# Altium schematic sheet True Variant.SchDoc("True Variant")
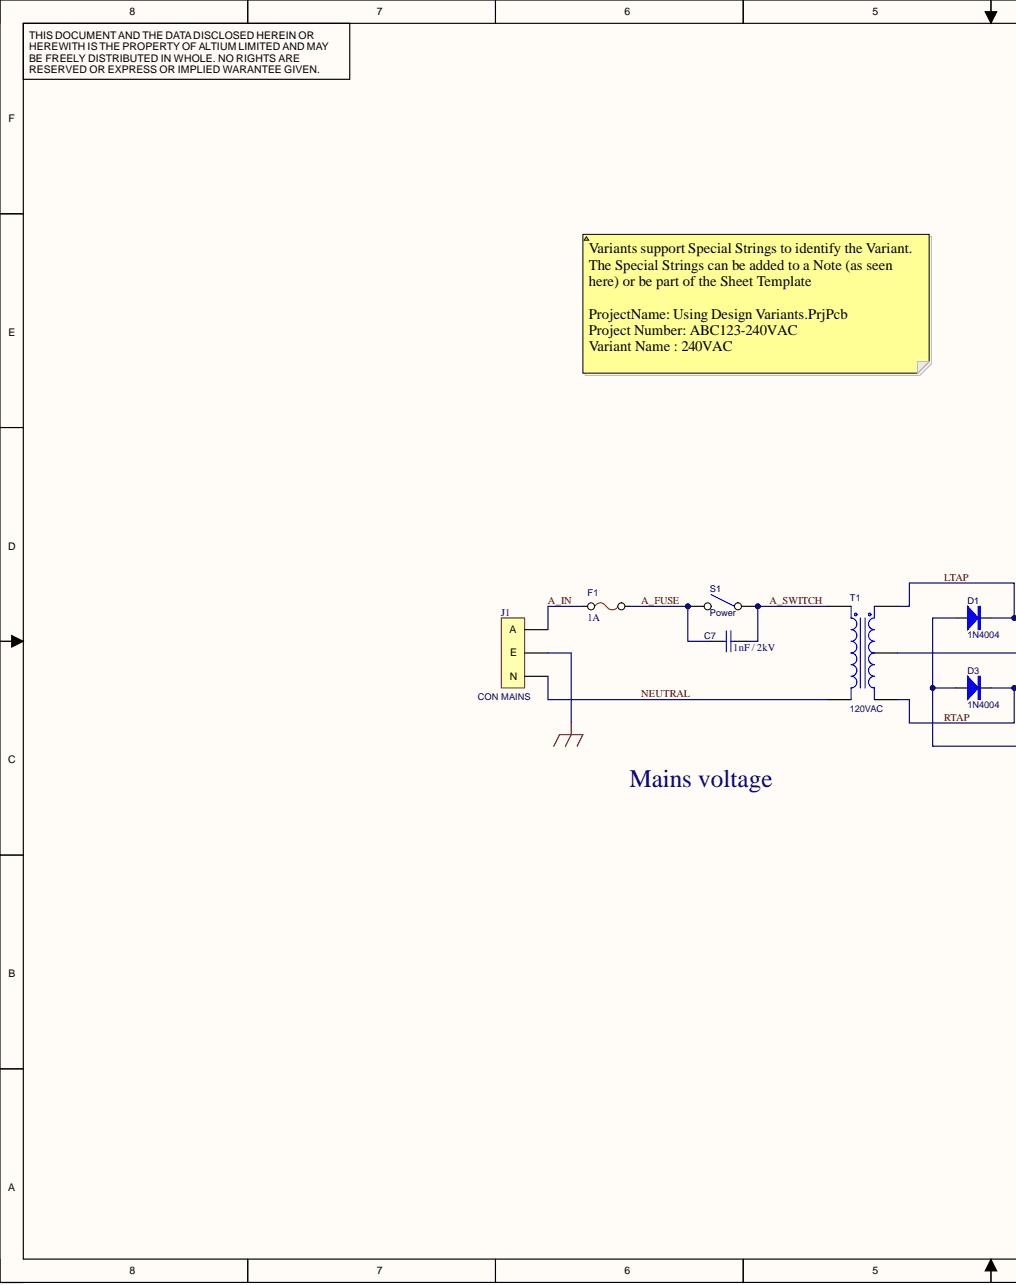
[diagram p0: True Variant.SchDoc, left]
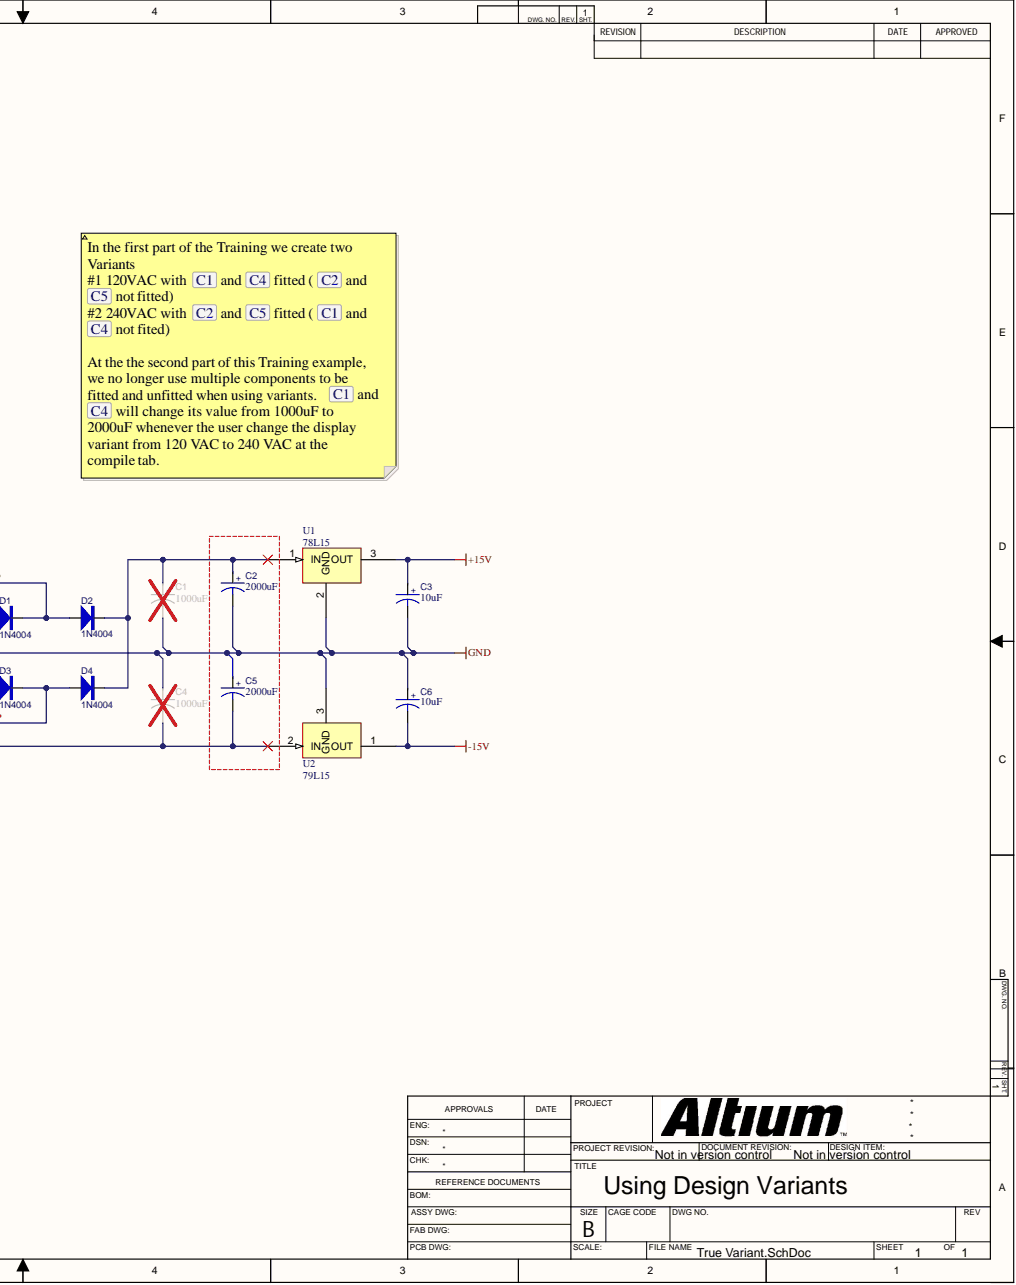
[diagram p1: True Variant.SchDoc, right]

## Parts
C1  1000uF  [RB.4/.8]
C2  2000uF  [RB.5/1.0]
C3  10uF  [RB.2/.4]
C4  1000uF  [RB.4/.8]
C5  2000uF  [RB.5/1.0]
C6  10uF  [RB.2/.4]
C7  1nF / 2kV  [RAD0.8]
D1  1N4004  [DIODE0.5]
D2  1N4004  [DIODE0.5]
D3  1N4004  [DIODE0.5]
D4  1N4004  [DIODE0.5]
F1  1A  [FUSE]
J1  CON MAINS  [IEC-MAINS]
S1  Power  [SW-MAINS]
T1  120VAC  [TRANSFORMER]
U1  78L15  [TO-92A]
U2  79L15  [TO-92A]

## Nets
+15V: C3.1 U1.3
-15V: C6.2 U2.1
CHASSIS: J1.2
GND: C1.2 C2.2 C3.2 C4.1 C5.1 C6.1 T1.4 U1.2 U2.3
A_FUSE: C7.2 F1.2 S1.2
A_IN: F1.1 J1.1
A_SWITCH: C7.1 S1.1 T1.1
LTAP: D1.2 D2.1 T1.3
NEUTRAL: J1.3 T1.2
RTAP: D3.2 D4.1 T1.5
NetC1_1: C1.1 C2.1 D2.2 D4.2 U1.1
NetC4_2: C4.2 C5.2 D1.1 D3.1 U2.2

## Library data of the parts
C1 C4 = CAP1000uF: Bi-Polar Capacitor; Component Kind: Standard; Simulation: CAP; Library Name: True Variant.SCHLIB
C2 C5 = CAPACITOR POL2: Bi-Polar Capacitor; Component Kind: Standard; Simulation: CAP; Library Name: True Variant.SCHLIB
C3 C6 = CAPACITOR POL: Bi-Polar Capacitor; Component Kind: Standard; Simulation: CAP
C7 = CAP: Capacitor; Component Kind: Standard; Simulation: CAP
D1-D4 = 1N4004: Diode; Component Kind: Standard; Simulation: 1N4004
F1 = FUSE: Component Kind: Standard; Description: Fuse; Simulation: FUSE
J1 = CON MAINS: Connector; Component Kind: Standard
S1 = SW SPST: Component Kind: Standard
T1 = 120VAC: Center-Tapped Transformer; Component Kind: Standard; Library Name: True Variant.SCHLIB
U1 = 78L15: Very Low Drop Voltage Regulators With Inhibit; Component Kind: Standard
U2 = 79L15: Very Low Drop Voltage Regulators With Inhibit; Component Kind: Standard
